# Altium schematic sheet HDMI.SchDoc
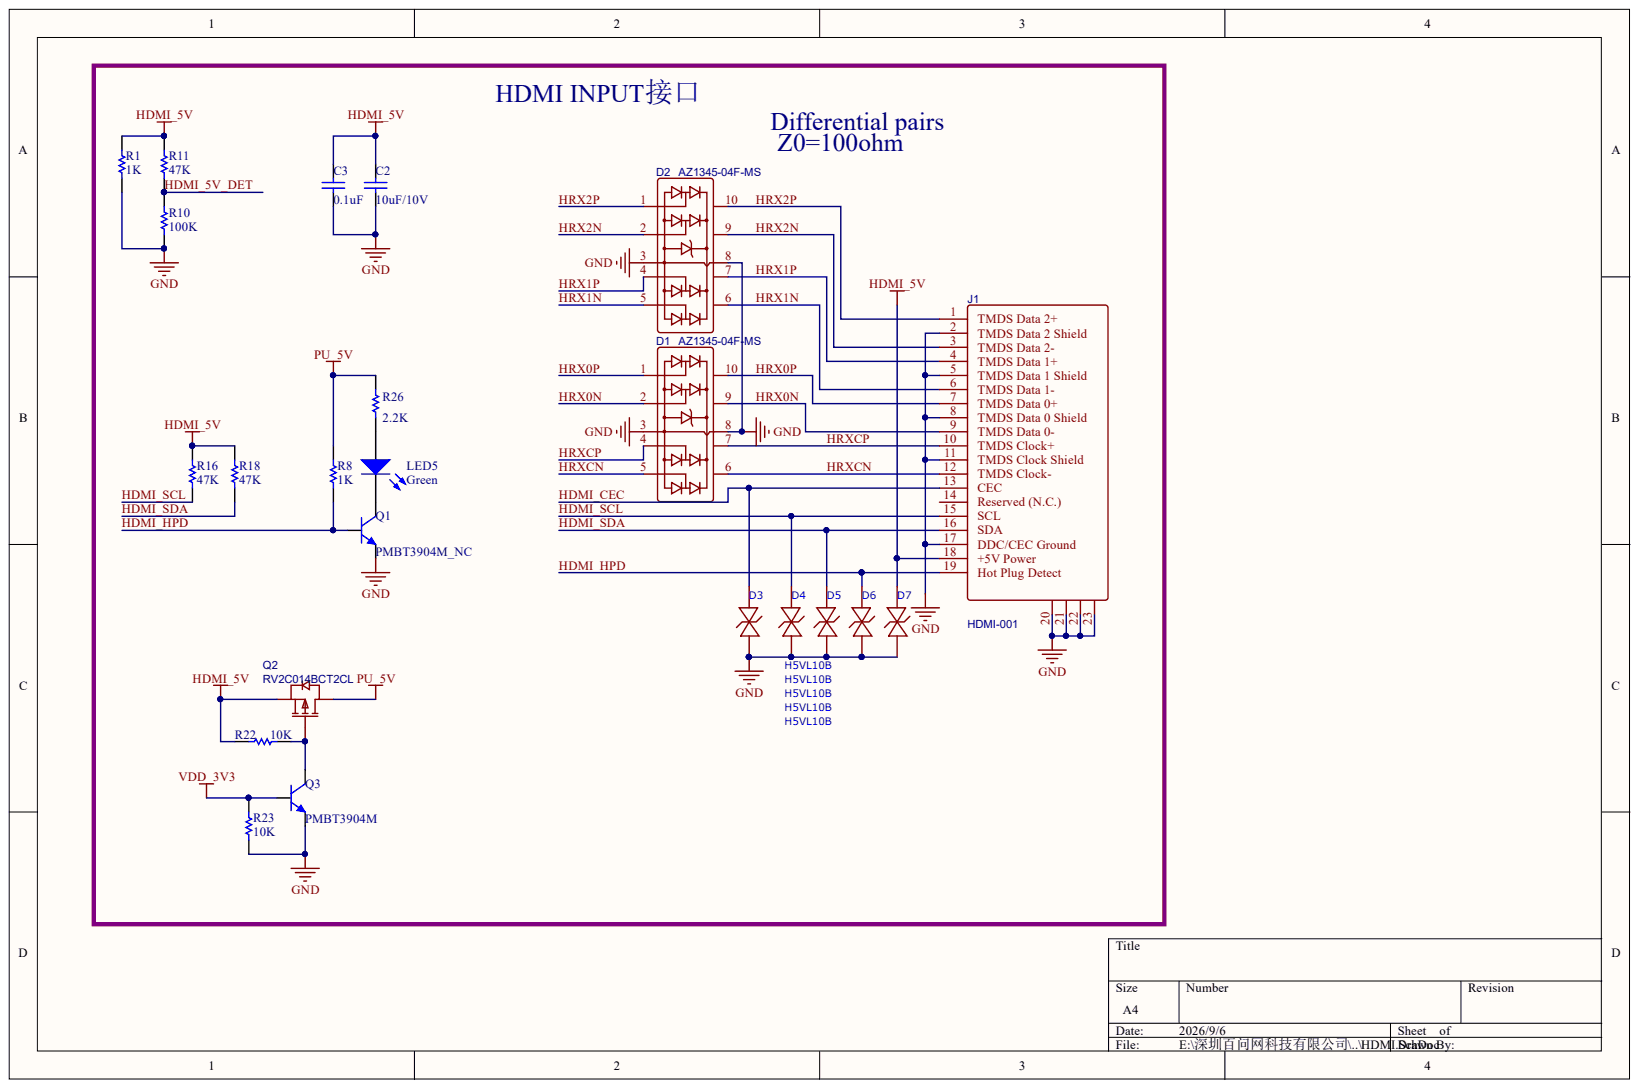
[diagram p0: HDMI.SchDoc]

## Parts
C2  10uF/10V  [0402]
C3  0.1uF  [0201_CAP_SMT]
D1  AZ1345-04F-MS  [DFN2510-10_L2.5-W1.0-P0.50-BL]
D2  AZ1345-04F-MS  [DFN2510-10_L2.5-W1.0-P0.50-BL]
D3  H5VL10B  [SOD-882_L1.0-W0.6-BI]
D4  H5VL10B  [SOD-882_L1.0-W0.6-BI]
D5  H5VL10B  [SOD-882_L1.0-W0.6-BI]
D6  H5VL10B  [SOD-882_L1.0-W0.6-BI]
D7  H5VL10B  [SOD-882_L1.0-W0.6-BI]
J1  HDMI-001  [HDMI-SMD_19P标准插座]
LED5  Green  [LED0402]
Q1  PMBT3904M_NC  [SC-101_L1.1-W0.7-P0.35-LS1.1-BL]
Q2  RV2C014BCT2CL  [SC-101_L1.1-W0.7-P0.35-LS1.1-BL]
Q3  PMBT3904M  [SC-101_L1.1-W0.7-P0.35-LS1.1-BL]
R1  1K  [0603]
R8  1K  [0201_RES_SMT]
R10  100K  [0201_RES_SMT]
R11  47K  [0201_RES_SMT]
R16  47K  [0201_RES_SMT]
R18  47K  [0201_RES_SMT]
R22  10K  [0201_RES_SMT]
R23  10K  [0201_RES_SMT]
R26  2.2K  [0201_RES_SMT]

## Nets
GND: C2.1 C3.1 D1.3 D1.8 D2.3 D2.8 D3.1 D4.1 D5.1 D6.1 D7.1 J1.2(TMDS_Data_2_Shield) J1.5(TMDS_Data_1_Shield) J1.8(TMDS_Data_0_Shield) J1.11(TMDS_Clock_Shield) J1.17(DDC/CEC_Ground) J1.20 J1.21 J1.22 J1.23 Q1.2 Q3.2 R1.2 R10.2 R23.1
HDMI_5V: C2.2 C3.2 D7.2 J1.18(+5V_Power) Q2.2 R1.1 R11.1 R16.1 R18.1 R22.1
PU_5V: Q2.3 R8.2 R26.1
VDD_3V3: Q3.1 R23.2
HDMI_5V_DET: R10.1 R11.2
HDMI_CEC: D3.2 J1.13(CEC)
HDMI_HPD: D6.2 J1.19(Hot_Plug_Detect) Q1.1 R8.1
HDMI_SCL: D4.2 J1.15(SCL) R16.2
HDMI_SDA: D5.2 J1.16(SDA) R18.2
HRX0N: D1.2 D1.9 J1.9(TMDS_Data_0-)
HRX0P: D1.1 D1.10 J1.7(TMDS_Data_0+)
HRX1N: D2.5 D2.6 J1.6(TMDS_Data_1-)
HRX1P: D2.4 D2.7 J1.4(TMDS_Data_1+)
HRX2N: D2.2 D2.9 J1.3(TMDS_Data_2-)
HRX2P: D2.1 D2.10 J1.1(TMDS_Data_2+)
HRXCN: D1.5 D1.6 J1.12(TMDS_Clock-)
HRXCP: D1.4 D1.7 J1.10(TMDS_Clock+)
NetLED5_1: LED5.1 R26.2
NetLED5_2: LED5.2 Q1.3
NetQ2_1: Q2.1 Q3.3 R22.2

Not connected: J1 14(Reserved_(N.C.))

## Library data of the parts
C2 C3 = Cap: Capacitor; PartNumber: CAP100NF; Component Kind: Standard; LatestRevisionDate: 17-Jul-2002; LatestRevisionNote: Re-released for DXP Platform.; Library Name: Miscellaneous Devices.IntLib; PackageReference: 0402; Published: 8-Jun-2000; Signal Integrity: Cap; Simulation: CAP; Publisher: Altium Limited
  C2: Value: 10uF/10V
  C3: Value: 0.1uF
D1 D2 = AZ1345-04F-MS: Contributor: LCSC; BOM_Manufacturer Part: AZ1345-04F-MS; BOM_Supplier: LCSC; BOM_Supplier Part: C2847056; Component Kind: Standard; spicePre: D; spiceSymbolName: AZ1345-04F-MS
J1 = HDMI-001: BOM_Manufacturer: Boom Precision Elec; Contributor: LCSC; BOM_JLCPCB Part Class: Extended Part; BOM_Manufacturer Part: HDMI-001; BOM_Supplier: LCSC; BOM_Supplier Part: C138388; Component Kind: Standard; Library Name: HM2.SchLib; spicePre: A; spiceSymbolName: HDMI-001
LED5 = LED2: Typical RED, GREEN, YELLOW, AMBER GaAs LED; Component Kind: Standard; LatestRevisionDate: 17-Jul-2002; LatestRevisionNote: Re-released for DXP Platform.; Library Name: Miscellaneous Devices.IntLib; PackageDescription: SM LED; 2 Flat Leads; PackageReference: 3.2X1.6X1.1; PackageVersion: Feb-2002; Published: 8-Jun-2000; Simulation: LED2; Value: Green; Publisher: Altium Limited
Q1 Q3 = 8050-SMD: 高频放大-NPN型; BOM名称: 高频放大-NPN型; Component Kind: Standard; JLC NO: C65692; Library Name: STM32F407_CORE_BOARD.SCHLIB
Q2 = AO3401A: BOM_Manufacturer Part: SI2301; BOM_LCSC Assembly: Yes; BOM_Supplier: LCSC; Component Kind: Standard; JLC NO: C162066; Library Name: HM2.SchLib; spicePre: Q; spiceSymbolName: SI2301
R1 R8 R10 R11 R16 R18 R22 R23 R26 = Res1: Resistor; PartNumber: RES2.2K; Component Kind: Standard; LatestRevisionDate: 17-Jul-2002; LatestRevisionNote: Re-released for DXP Platform.; Library Name: Miscellaneous Devices.IntLib; PackageReference: 0402; Published: 8-Jun-2000; Signal Integrity: Res; Simulation: RESISTOR; Publisher: Altium Limited
  R1 R8: Value: 1K
  R10: Value: 100K
  R11 R16 R18: Value: 47K
  R22 R23: Value: 10K
  R26: Value: 2.2K
D3-D7 = H5VL10B: Component Kind: Standard; JLC NO: C7420372
